# Altium schematic sheet 08-SwitchingRegulator.SchDoc
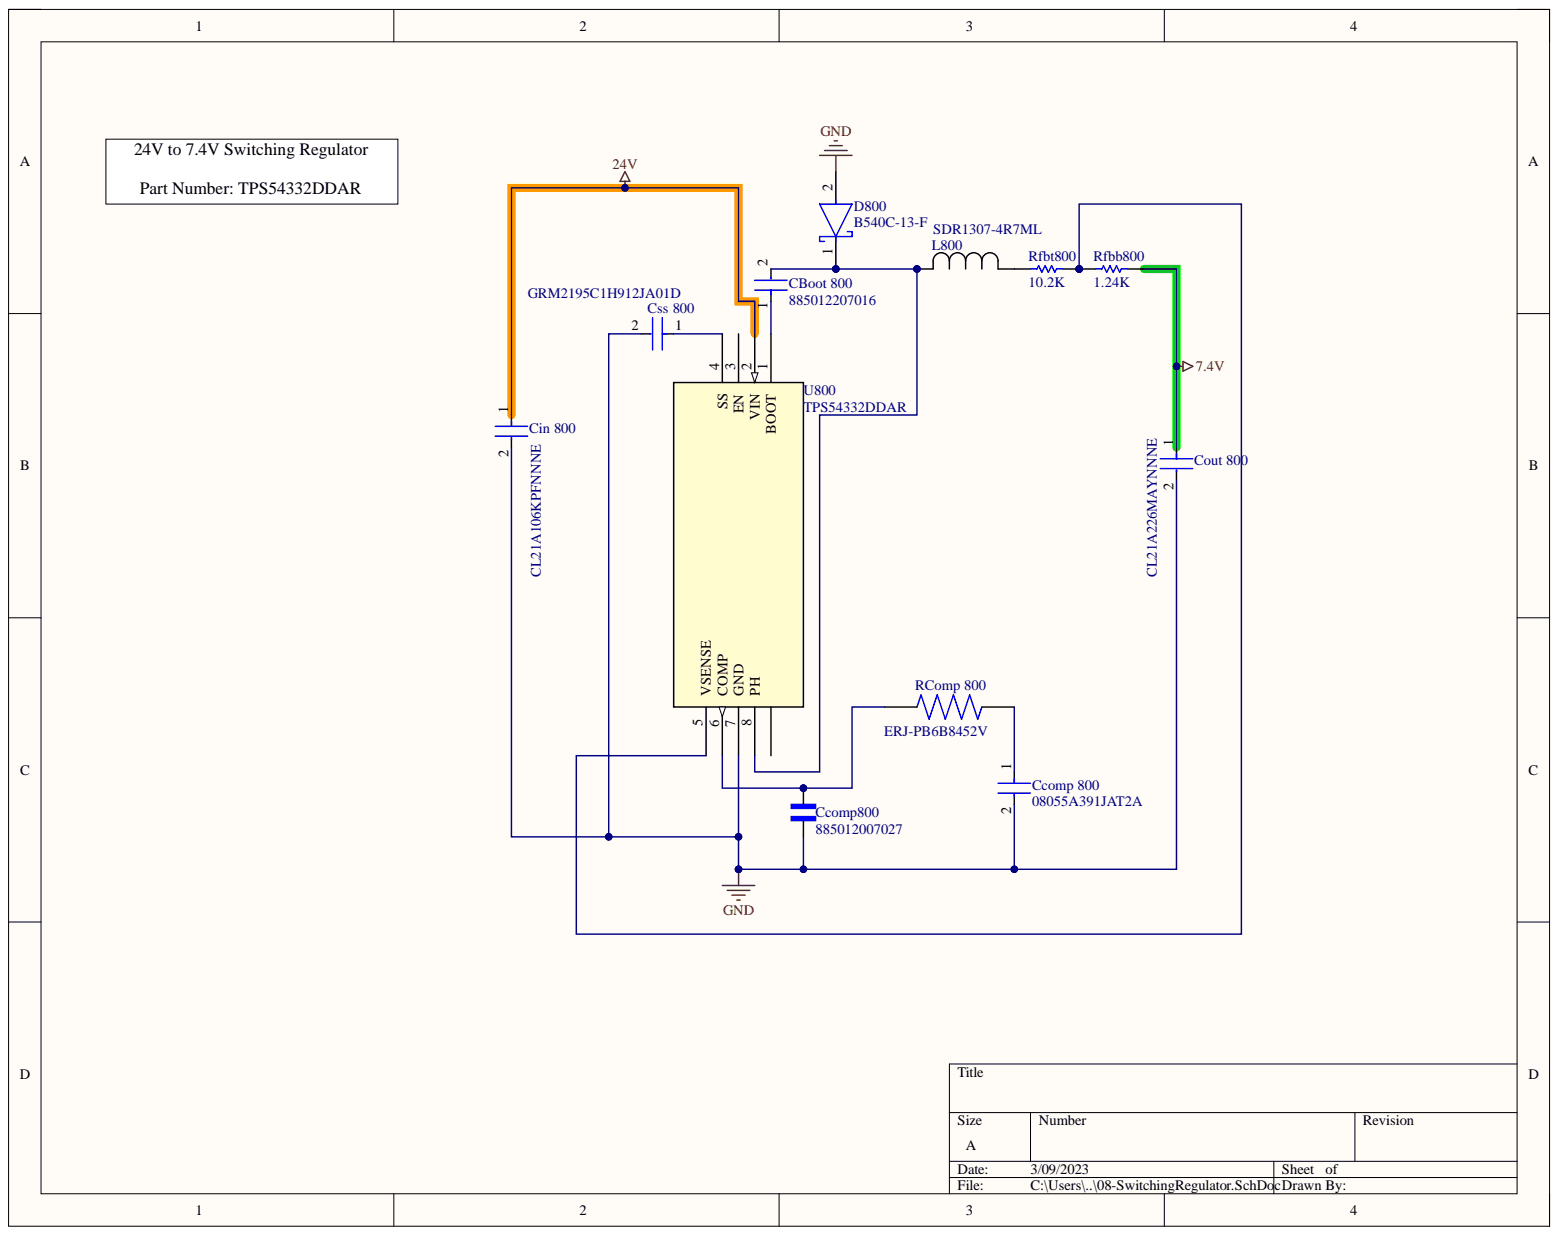
[diagram p0: 08-SwitchingRegulator.SchDoc]

## Parts
C800  885012207016  [FP-885012207016-MFG]
CBoot_800  885012207016  [FP-885012207016-MFG]
Ccomp800  885012007027  [WCAP-CSGP_885012007027]
Ccomp_800  08055A391JAT2A  [FP-0805-L_2_01_0_2-W_1_25-IPC_C]
Cin_800  CL21A106KPFNNNE  [FP-CL21-IPC_B]
Cout_800  CL21A226MAYNNNE  [FP-CL21-IPC_C]
Css_800  GRM2195C1H912JA01D  [FP-GRM219-0_1-MFG]
D800  B540C-13-F  [FP-SMC-MFG]
L800  SDR1307-4R7ML
RComp_800  ERJ-PB6B8452V  [RES_ERJ-PB6B8452V]
Rfbb800  value 1.24K  (hidden comment: RA73F2A1K24BTD)
Rfbt800  value 10.2K  (hidden comment: RA73F2A10K2BTD)
U800  TPS54332DDAR  [DDA8_3X2P13]

## Nets
7.4V: Cout_800.1 Rfbb800.1
24V: Cin_800.1 U800.2(VIN)
GND: Ccomp800.1 Ccomp_800.2 Cin_800.2 Cout_800.2 Css_800.2 D800.2 U800.7(GND)
NetCBoot 800_1: CBoot_800.1 U800.1(BOOT)
NetCBoot 800_2: CBoot_800.2 D800.1 L800.2 U800.8(PH)
NetCcomp800_2: Ccomp800.2 RComp_800.2 U800.6(COMP)
NetCcomp 800_1: Ccomp_800.1 RComp_800.1
NetCss 800_1: Css_800.1 U800.4(SS)
NetL800_1: L800.1 Rfbt800.2
NetRfbb800_2: Rfbb800.2 Rfbt800.1 U800.5(VSENSE)

Not connected: C800 1 2; U800 3(EN) EPAD

## Library data of the parts
C800 CBoot_800 = CMP-207839-000050-1: CAP CER 0.1UF 10V X7R 0805; Capacitance: 100nF; Tolerance: 10%; Case Code (Imperial): 0805; Case Code (Metric): 2012; Case/Package: 0805; Component Kind: Standard; Length: 0.079inch; Library Name: Altium Content Vault; Max Operating Temperature: 125°C; Min Operating Temperature: -55°C; Mount: Surface Mount; Packaging: Cut Tape; RoHS Compliant: Yes; Series: WCAP-CSGP; Thickness: 0.035inch; Voltage Rating: 10V; Width: 0.049inch
Ccomp800 = 885012007027: <b> WCAP-CSGP Ceramic Capacitors <br> <br> <br><b>Characteristics </b><br> </b><br>Multilayer Ceramic Chip Capacitor <br>Recommended soldering:Reflow, for 0603 and 0805 wave soldering is also allowed <br>Operating temperature:-55 Â°C to +125 Â°C; <br>Ceramic characteristics: Class I - NPO ; Class II -X7R, X5R <br>High performance ceramic <br>High capacitance stability <br>Minimal aging  <br>Termination:Cu/Ni/Sn <br>   <br><a href="http://katalog.we-online.de/media/images/eisos/WCAP-CSGP_pf2.jpg" title="Enlarge picture"> <img src="http://katalog.we-online.de/media/thumbs2/eisos/thb_WCAP-CSGP_pf2.jpg" width="320"></a><p> Details see: <a href="http://katalog.we-online.de/en/pbs/WCAP-CSGP-0805?">http://katalog.we-online.de/en/pbs/WCAP-CSGP-0805? </a><p>Created:  2015-01-28 <br>2015 (C) Wurth Elektronik; Availability: Unavailable; Component Kind: Standard; Library Name: 885012007027.IntLib; MP: 885012007027; Package: None; Price: None; Supplier 1: Digi-Key; Supplier Part Number 1: 732-7826-1-ND
Ccomp_800 = CMP-2000-05807-2: General Purpose Ceramic Capacitor, 0805, 390pF, 5%, C0G, 30ppm/°C, 50V; Capacitance: 390pF; Case Code (Imperial): 0805; Case Code (Metric): 2012; Case/Package: 0805; Component Kind: Standard; Contact Plating: Tin; Depth: 1.25mm; Dielectric: C0G; Dielectric Material: Ceramic; Height: 0.94mm; Lead Free: Lead Free; Length: 2.01mm; Library Name: Altium Content Vault; Max Operating Temperature: 125°C; Min Operating Temperature: -55°C; Mount: Surface Mount; Number of Pins: 2; Package Quantity: 1; Packaging: Tape and Reel; Radiation Hardening: No; REACH SVHC: No SVHC; RoHS Compliant: Yes; Temperature Coefficient: 30ppm/°C; Termination: SMD/SMT; Thickness: 0.037inch; Tolerance: 5%; Voltage: 50V; Voltage Rating: 50V; Voltage Rating (DC): 50V; Width: 1.25mm
Cin_800 = CMP-2000-06103-2: CL21 Series 0805 10uF 10V ±10% Tolerance X5R Multilayer Ceramic Chip Capacitor; Capacitance: 10uF; Case Code (Imperial): 0805; Case Code (Metric): 2012; Case/Package: 0805; Component Kind: Standard; Contact Plating: Tin; Depth: 1.25mm; Dielectric: X5R; Height: 1.25mm; Lead Free: Lead Free; Length: 2mm; Library Name: Altium Content Vault; Max Operating Temperature: 85°C; Min Operating Temperature: -55°C; Mount: Surface Mount; Package Quantity: 1; Packaging: Tape and Reel; Radiation Hardening: No; Thickness: 0.053inch; Tolerance: 10%; Voltage Rating: 10V; Voltage Rating (DC): 10V; Width: 0.049inch
Cout_800 = CMP-13271-003225-1: CL21 Series 0805 22uF 25V ±20% Tolerance X5R Multilayer Ceramic Chip Capacitor; Component Kind: Standard; Library Name: Altium Content Vault
Css_800 = CMP-2007-00147-2: Chip Multilayer Ceramic Capacitors for General Purpose, 0805, 9100pF, C0G, 30ppm/°C, 5%, 50V; Capacitance: 9.1nF; Case Code (Imperial): 0805; Case Code (Metric): 2012; Case/Package: 0805; Component Kind: Standard; Depth: 1.25mm; Dielectric: C0G; Height: 0.85mm; Lead Free: Lead Free; Length: 2mm; Library Name: Altium Content Vault; Max Operating Temperature: 125°C; Min Operating Temperature: -55°C; Mount: Surface Mount; Package Quantity: 1; Packaging: Tape and Reel; Radiation Hardening: No; Termination: SMD/SMT; Thickness: 0.037inch; Tolerance: 5%; Voltage: 50V; Voltage Rating: 50V; Voltage Rating (DC): 50V; Width: 0.049inch
D800 = CMP-2000-05097-3: DIODE SCHOTTKY 40V 5A SMC; Manufacturer: Diodes Inc.; Automotive Grade: NA; Capacitance: 300pF; Max Junction Temp: +150°C; Max Surge Forward Current: 100A; Peak Reverse Current: 0.5mA; Standoff Height: 0.1mm; Value: 300pF; Automotive: No; Category: Diode; Component Kind: Standard; ComponentLink2Description: Datasheet; ComponentLink2URL: https://www.diodes.com/assets/Datasheets/ds13012.pdf; Device Class L1: Discrete Semiconductors; Device Class L2: Diodes; Device Class L3: Schottky Diodes; Digikey Description: DIODE SCHOTTKY 40V 5A SMC; Footprint Url: https://www.diodes.com/assets/Package-Files/SMC.pdf; Forward Current: 5A; Height: 2.5mm; Lead Free: Yes; Library Name: Altium Content Vault; Manufacturer Part Number: B540C-13-F; Max Repetitive Reverse Voltage: 40V; Mouser Description: Diode: Schottky rectifying; SMD; 40V; 5A; SMC; Package: reel, tape; Octopart: https://octopart.com/b540c-13-f-diodes+inc.-325873; Package: SMC; Reverse Voltage: 40V; RoHS: TRUE; Temperature: -55°C to +150°C; Temperature Range High: +150°C; Temperature Range Low: -55°C
L800 = SDR1307-4R7ML: No Description Available; Mfr_Name: Bourns Electronics; Component Kind: Standard; Copyright: Copyright (C) 2023 Ultra Librarian. All rights reserved.; Digi-Key_Part_Number_1: SDR1307-4R7MLCT-ND; Digi-Key_Part_Number_2: SDR1307-4R7MLDKR-ND; Digi-Key_Part_Number_3: SDR1307-4R7MLTR-ND; Library Name: SDR1307-4R7ML.SchLib; Manufacturer_Part_Number: SDR1307-4R7ML; Supplier 1: Digi-Key; Supplier Part Number 1: SDR1307-4R7MLCT-ND
RComp_800 = ERJ-PB6B8452V: MF: {'manufacturer': u'Panasonic'}; Availability: Unavailable; Component Kind: Standard; Library Name: ERJ-PB6B8452V.IntLib; MP: ERJ-PB6B8452V; Package: None; Price: None; Supplier 1: Digi-Key; Supplier Part Number 1: P20814CT-ND
Rfbb800 = Resistor: RA73F2A1K24BTD https://www.digikey.com/en/products/detail/te-connectivity-passive-product/RA73F2A1K24BTD/16030904; SimulationGenericComponent: True; TC1: 0; Component Kind: Standard; Library Name: Simulation Generic Components.IntLib; Simulation: R_Resistor_Default; TC2: 0
Rfbt800 = Resistor: RA73F 2A 10K2 0.1% 5K RL https://www.digikey.com/en/products/detail/te-connectivity-passive-product/RA73F2A10K2BTD/16031520; SimulationGenericComponent: True; TC1: 0; Component Kind: Standard; Library Name: Simulation Generic Components.IntLib; Simulation: R_Resistor_Default; TC2: 0
U800 = TPS54332DDAR: IC REG BUCK ADJ 3.5A 8SOPWRPAD; Manufacturer: Texas Instruments; Max Output Voltage: 26.04V; Max Supply Voltage: 28V; Min Input Voltage: 3.5V; Min Output Voltage: 800mV; Number of Outputs: 1; Output Configuration: Positive; Output Type: Adjustable; Output Voltage: 25V; Quiescent Current: 82uA; Switching Frequency: 1MHz; Synchronous Rectifier: No; Case/Package: HSOP; Category: Voltage Regulators - Switching; Component Kind: Standard; ComponentLink1Description: https://datasheet.ciiva.com/14378/tps54332-14378090.pdf?src-supplier=Avnet; ComponentLink1URL: https://datasheet.ciiva.com/14378/tps54332-14378090.pdf?src-supplier=Avnet; ComponentLink2Description: https://datasheet.ciiva.com/2034/tps54332-2034909.pdf?src-supplier=Digi-Key; ComponentLink2URL: https://datasheet.ciiva.com/2034/tps54332-2034909.pdf?src-supplier=Digi-Key; Contact Plating: Gold; Copyright: Copyright (C) 2023 Ultra Librarian. All rights reserved.; Digi-Key_Part_Number_1: 296-39420-1-ND; Digi-Key_Part_Number_2: 296-39420-2-ND; Digi-Key_Part_Number_3: 296-39420-6-ND; Digi-Key_Part_Number_4: TPS54332DDAR-ND; Height: 1.55mm; Lead Free: Contains Lead; Length: 5mm; Library Name: TPS54332DDAR.SchLib; Manufacturer Part Number: TPS54332DDAR; Manufacturer_Part_Number: TPS54332DDAR; Max Operating Temperature: 150°C; Max Output current: 3.5A; Mfr_Name: Texas Instruments; Min Operating Temperature: -40°C; Min Supply Voltage: 3.5V; Mounting Technology: Surface Mount; Operating Supply Voltage: 28V; Output Current: 3.5A; Package Quantity: 2500; Packaging: Tape and Reel; Pins: 8; Pricing: 1=2.19000, 10=1.96600, 25=1.85520, 100=1.58050, 250=1.48400, 500=1.29850, 1000=1.07590 (USD); Radiation Hardening: No; Reach SVHC Compliant: Yes; RefDes: RefDes; RoHS: Yes; RoHS Compliant: Yes; Series: Eco-Mode™; Stock: 11262; Supplier: Digi-Key; Supplier 1: Digi-Key; Supplier Part Number: 296-39420-1-ND; Supplier Part Number 1: 296-39420-1-ND; Type: Type; Weight: 0.00249oz; Width: 4mm
